# Altium schematic sheet BSPD.SchDoc
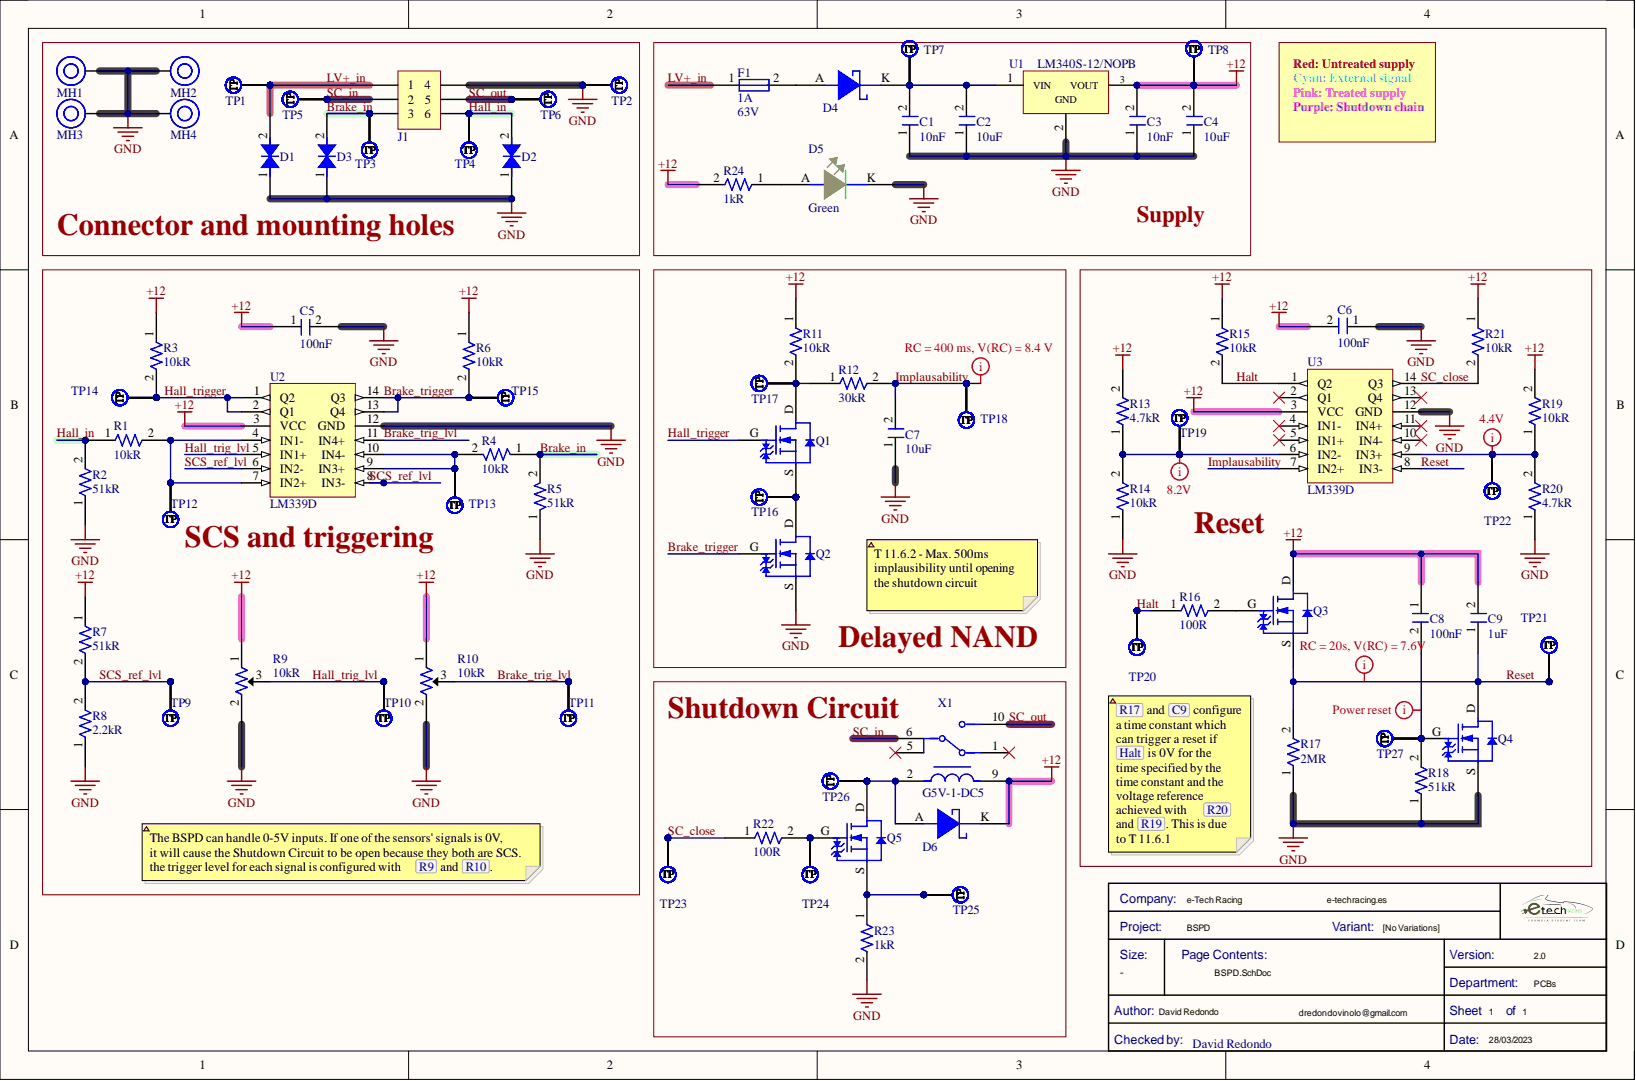
[diagram p0: BSPD.SchDoc]

## Parts
C1  Capacitance: 10nF  [C1206FNPC-RW]  (hidden comment: 885012008055)
C2  Capacitance: 10uF  [C10u-50V-1210FNPMLCC-X7R]  (hidden comment: GRJ32ER71H106KE11L)
C3  Capacitance: 10nF  [C1206FNPC-RW]  (hidden comment: 885012008055)
C4  Capacitance: 10uF  [C10u-50V-1210FNPMLCC-X7R]  (hidden comment: GRJ32ER71H106KE11L)
C5  Capacitance: 100nF  [C1206FNPC-RW]  (hidden comment: 885012208058)
C6  Capacitance: 100nF  [C1206FNPC-RW]  (hidden comment: 885012208058)
C7  Capacitance: 10uF  [C1206FNPC-RW]  (hidden comment: 885012208018)
C8  Capacitance: 100nF  [C1206FNPC-RW]  (hidden comment: 885012208058)
C9  Capacitance: 1uF  [C1206FNPC-RW]  (hidden comment: 885012208036)
D1  824551301  [DTVS-DO-214AB]  (value not drawn)
D2  824501600  [DTVS-DO-214AC]  (value not drawn)
D3  824501600  [DTVS-DO-214AC]  (value not drawn)
D4  MBR0530  [DSC-SOD123]  (value not drawn)
D5  Color: Green  [LED_0805_WE]  (hidden comment: 150080VS75000)
D6  MBR0530  [DSC-SOD123]  (value not drawn)
F1  Current Rating: 1A  Voltage Rating (AC): 63V  [Fuse_1206]  (hidden comment: 0437001.WRA)
J1  J_NanoFit_2x3  [J_NanoFit_2x3]  (value not drawn)
MH1  Mounting_Hole_M3  [Mounting_Hole_M3]  (value not drawn)
MH2  Mounting_Hole_M3  [Mounting_Hole_M3]  (value not drawn)
MH3  Mounting_Hole_M3  [Mounting_Hole_M3]  (value not drawn)
MH4  Mounting_Hole_M3  [Mounting_Hole_M3]  (value not drawn)
Q1  CPH3455-TL-H  [TRT-NCH-3/35-CPH]  (value not drawn)
Q2  CPH3455-TL-H  [TRT-NCH-3/35-CPH]  (value not drawn)
Q3  CPH3455-TL-H  [TRT-NCH-3/35-CPH]  (value not drawn)
Q4  CPH3455-TL-H  [TRT-NCH-3/35-CPH]  (value not drawn)
Q5  CPH3455-TL-H  [TRT-NCH-3/35-CPH]  (value not drawn)
R1  Resistance: 10kR  [R1206FTK-W]  (hidden comment: CRCW120610K0FKEA)
R2  Resistance: 51kR  [R1206FTK-W]  (hidden comment: CRCW120610K0FKEA)
R3  Resistance: 10kR  [R1206FTK-W]  (hidden comment: CRCW120610K0FKEA)
R4  Resistance: 10kR  [R1206FTK-W]  (hidden comment: CRCW120610K0FKEA)
R5  Resistance: 51kR  [R1206FTK-W]  (hidden comment: CRCW120610K0FKEA)
R6  Resistance: 10kR  [R1206FTK-W]  (hidden comment: CRCW120610K0FKEA)
R7  Resistance: 51kR  [R1206FTK-W]  (hidden comment: CRCW120610K0FKEA)
R8  Resistance: 2.2kR  [R1206FTK-W]  (hidden comment: CR1206-FX-2201ELF)
R9  Resistance: 10kR  [R10k-VTR]  (hidden comment: 3296W-1-103LF)
R10  Resistance: 10kR  [R10k-VTR]  (hidden comment: 3296W-1-103LF)
R11  Resistance: 10kR  [R1206FTK-W]  (hidden comment: CRCW120610K0FKEA)
R12  Resistance: 30kR  [R1206FTK-W]  (hidden comment: CRCW120610K0FKEA)
R13  Resistance: 4.7kR  [R1206FTK-W]  (hidden comment: CRS1206-FX-4701ELF)
R14  Resistance: 10kR  [R1206FTK-W]  (hidden comment: CRCW120610K0FKEA)
R15  Resistance: 10kR  [R1206FTK-W]  (hidden comment: CRCW120610K0FKEA)
R16  Resistance: 100R  [R1206FTK-W]  (hidden comment: CRG1206F100R)
R17  Resistance: 2MR  [R1206FTK-W]  (hidden comment: HVC1206-2M0FT3)
R18  Resistance: 51kR  [R1206FTK-W]  (hidden comment: CRCW120610K0FKEA)
R19  Resistance: 10kR  [R1206FTK-W]  (hidden comment: CRCW120610K0FKEA)
R20  Resistance: 4.7kR  [R1206FTK-W]  (hidden comment: CRS1206-FX-4701ELF)
R21  Resistance: 10kR  [R1206FTK-W]  (hidden comment: CRCW120610K0FKEA)
R22  Resistance: 100R  [R1206FTK-W]  (hidden comment: CRG1206F100R)
R23  Resistance: 1kR  [R1206FTK-W]  (hidden comment: ERJT08J102V)
R24  Resistance: 1kR  [R0805FTK]  (hidden comment: CR0805-JW-102ELF)
TP1  TestPoint  [TestPoint]  (value not drawn)  (no BOM)
TP2  TestPoint  [TestPoint]  (value not drawn)  (no BOM)
TP3  TestPoint  [TestPoint]  (value not drawn)  (no BOM)
TP4  TestPoint  [TestPoint]  (value not drawn)  (no BOM)
TP5  TestPoint  [TestPoint]  (value not drawn)  (no BOM)
TP6  TestPoint  [TestPoint]  (value not drawn)  (no BOM)
TP7  TestPoint  [TestPoint]  (value not drawn)  (no BOM)
TP8  TestPoint  [TestPoint]  (value not drawn)  (no BOM)
TP9  TestPoint  [TestPoint]  (value not drawn)  (no BOM)
TP10  TestPoint  [TestPoint]  (value not drawn)  (no BOM)
TP11  TestPoint  [TestPoint]  (value not drawn)  (no BOM)
TP12  TestPoint  [TestPoint]  (value not drawn)  (no BOM)
TP13  TestPoint  [TestPoint]  (value not drawn)  (no BOM)
TP14  TestPoint  [TestPoint]  (value not drawn)  (no BOM)
TP15  TestPoint  [TestPoint]  (value not drawn)  (no BOM)
TP16  TestPoint  [TestPoint]  (value not drawn)  (no BOM)
TP17  TestPoint  [TestPoint]  (value not drawn)  (no BOM)
TP18  TestPoint  [TestPoint]  (value not drawn)  (no BOM)
TP19  TestPoint  [TestPoint]  (value not drawn)  (no BOM)
TP20  TestPoint  [TestPoint]  (value not drawn)  (no BOM)
TP21  TestPoint  [TestPoint]  (value not drawn)  (no BOM)
TP22  TestPoint  [TestPoint]  (value not drawn)  (no BOM)
TP23  TestPoint  [TestPoint]  (value not drawn)  (no BOM)
TP24  TestPoint  [TestPoint]  (value not drawn)  (no BOM)
TP25  TestPoint  [TestPoint]  (value not drawn)  (no BOM)
TP26  TestPoint  [TestPoint]  (value not drawn)  (no BOM)
TP27  TestPoint  [TestPoint]  (value not drawn)  (no BOM)
U1  LM340S-12/NOPB  [LDO_35V-1/12V-TO220]
U2  LM339D  [Array_4_Amp_LM339D]
U3  LM339D  [Array_4_Amp_LM339D]
X1  G5V-1-DC5  [Relay_G5V-1-DC5]

## Nets
+12: C3.2 C4.2 C5.1 C6.2 C8.1 C9.2 D6.K Q3.D R3.1 R6.1 R7.1 R9.1 R10.1 R11.1 R13.2 R15.1 R19.2 R21.1 R24.2 TP8.1 U1.3 U2.3(VCC) U3.3(VCC) X1.9
GND: C1.1 C2.1 C3.1 C4.1 C5.2 C6.1 C7.1 D1.1 D2.1 D3.1 D5.K J1.4 MH1.1 MH2.1 MH3.1 MH4.1 Q2.S Q4.S R2.1 R5.1 R8.1 R9.2 R10.2 R14.1 R17.1 R18.1 R20.1 R23.2 TP2.1 U1.2 U2.12(GND) U3.12(GND)
Brake_in: D3.2 J1.3 R4.1 R5.2 TP3.1
Brake_trig_lvl: R10.3 TP11.1 U2.11(IN4+)
Brake_trigger: Q2.G R6.2 TP15.1 U2.13(Q4) U2.14(Q3)
Hall_in: D2.2 J1.6 R1.1 R2.2 TP4.1
Hall_trig_lvl: R9.3 TP10.1 U2.5(IN1+)
Hall_trigger: Q1.G R3.2 TP14.1 U2.1(Q2) U2.2(Q1)
Halt: R15.2 R16.1 TP20.1 U3.1(Q2)
Implausability: C7.2 R12.2 TP18.1 U3.7(IN2+)
LV+_in: D1.2 F1.1 J1.1 TP1.1
Reset: C9.1 Q3.S Q4.D R17.2 TP21.1 U3.8(IN3-)
SC_close: R21.2 R22.1 TP23.1 U3.14(Q3)
SC_in: J1.2 TP5.1 X1.6
SC_out: J1.5 TP6.1 X1.10
SCS_ref_lvl: R7.2 R8.2 TP9.1 U2.6(IN2-) U2.8(IN3-)
NetC1_2: C1.2 C2.2 D4.K TP7.1 U1.1
NetC8_2: C8.2 Q4.G R18.2 TP27.1
NetD4_A: D4.A F1.2
NetD5_A: D5.A R24.1
NetD6_A: D6.A Q5.D TP26.1 X1.2
NetQ1_D: Q1.D R11.2 R12.1 TP17.1
NetQ1_S: Q1.S Q2.D TP16.1
NetQ3_G: Q3.G R16.2
NetQ5_G: Q5.G R22.2 TP24.1
NetQ5_S: Q5.S R23.1 TP25.1
NetR1_2: R1.2 TP12.1 U2.4(IN1-) U2.7(IN2+)
NetR4_2: R4.2 TP13.1 U2.9(IN3+) U2.10(IN4-)
NetR13_1: R13.1 R14.2 TP19.1 U3.6(IN2-)
NetR19_1: R19.1 R20.2 TP22.1 U3.9(IN3+)

Not connected: U3 2(Q1) 4(IN1-) 5(IN1+) 10(IN4-) 11(IN4+) 13(Q4); X1 1 5

## Library data of the parts
C1 C3 = C10n-50V-1206FNPC-C0G/NP0: Cap Cer 10000PF 50V C0G/NP0 1206; Manufacturer: Wurth Electronics; .Creator: DO; Tolerance: 5%; Case Code (Imperial): 1206; Case Code (Metric): 3216; Case/Package: 1206; Category: Ceramic Capacitors; Component Kind: Standard; ComponentLink1Description: https://katalog.we-online.de/pbs/datasheet/885012008055.pdf?src-supplier=Digi-Key; ComponentLink1URL: https://katalog.we-online.de/pbs/datasheet/885012008055.pdf?src-supplier=Digi-Key; ComponentLink2Description: Where buy it; ComponentLink2URL: https://www.we-online.com/catalog/en/WCAP-CSGP-50VDC#885012207092; Length: 0.126inch; Library Name: Passives.SchLib; Manufacturer Part Number: 885012008055; Max Operating Temperature: 125°C; Min Operating Temperature: -55°C; Mount: Surface Mount; Packaging: Tape and Reel; Pricing 1: 1=0,40000, 10=0,35800, 50=0,35800, 100=0,32100, 1000=0,28400, 10000=0,17200 (USD); RoHS: Yes; Stock 1: 4648; Supplier 1: Mouser; Supplier Part Number 1: 710-885012008055; Thickness: 0.053inch; Voltage Rating: 50V; Width: 0.063inch
C2 C4 = C10u-50V-1210FNPMLCC-X7R: Multilayer Ceramic Capacitors MLCC - SMD/SMT 1210 10uF 50volts X7R +/-10% Soft Term; Manufacturer: Murata; .Creator: DR; Tolerance: 10%; Voltage Rating: 50V; Case Code (Imperial): 1210; Case Code (Metric): 3225; Case/Package: 1210; Category: Ceramic Capacitors; Component Kind: Standard; ComponentLink1Description: Datasheet; ComponentLink1URL: https://eu.mouser.com/datasheet/2/281/GRJ32ER71H106KE11_01-1977929.pdf; Features: Soft Termination; Length: 0.126inch; Library Name: Passives.SchLib; Manufacturer Part Number: GRJ32ER71H106KE11L; Max Operating Temperature: 125°C; Min Operating Temperature: -55°C; Mount: Surface Mount; Packaging: Tape and Reel; Pricing 1: 1000=0,33327, 2000=0,32389, 4000=0,31450, 6000=0,30511, 8000=0,29572 (USD); RoHS: Yes; RoHS Compliant: Yes; Stock 1: 19000; Supplier 1: Avnet; Supplier Part Number 1: GRJ32ER71H106KE11L; Thickness: 0.11inch; Width: 0.098inch
C5 C6 C8 = C100n-25V-1206FNPC-X7R: Cap Cer 0.1UF 25V X7R 1206; Manufacturer: Wurth Electronics; .Creator: DO; Tolerance: 10%; Case Code (Imperial): 1206; Case Code (Metric): 3216; Case/Package: 1206; Category: Capacitor; Component Kind: Standard; ComponentLink1Description: Datasheet; ComponentLink1URL: https://www.we-online.com/catalog/datasheet/885012208058.pdf; ComponentLink2Description: Where buy it; ComponentLink2URL: https://www.we-online.com/catalog/en/WCAP-CSGP-25VDC#885012208058; ComponentLink3Description: https://katalog.we-online.de/pbs/datasheet/885012208058.pdf?src-supplier=Digi-Key; ComponentLink3URL: https://katalog.we-online.de/pbs/datasheet/885012208058.pdf?src-supplier=Digi-Key; Length: 0.126inch; Library Name: Passives.SchLib; Manufacturer Part Number: 885012208058; Max Operating Temperature: 125°C; Min Operating Temperature: -55°C; Mount: Surface Mount; Packaging: Tape and Reel; Pricing 1: 1=0,11000, 10=0,10100, 100=0,09100, 500=0,08000 (USD); RoHS: Yes; RoHS Compliant: Yes; Stock 1: 4375; Supplier 1: Digi-Key; Supplier Part Number 1: 732-8109-1-ND; Thickness: 0.035inch; Voltage Rating: 25V; Width: 0.063inch
C7 = C10u-10V-1206FNPC-X7R: Cap, 10ΜF, 10V, 10%, X7R, 1206; Manufacturer 1: Wurth Electronics; .Creator: GP; Case Code (Imperial): 1206; Case Code (Metric): 3216; Case/Package: 1206; Category: Ceramic Capacitors; Component Kind: Standard; ComponentLink1Description: http://www.farnell.com/datasheets/2031895.pdf?src-supplier=Farnell; ComponentLink1URL: http://www.farnell.com/datasheets/2031895.pdf?src-supplier=Farnell; ComponentLink2Description: https://katalog.we-online.de/pbs/datasheet/885012208018.pdf?src-supplier=Digi-Key; ComponentLink2URL: https://katalog.we-online.de/pbs/datasheet/885012208018.pdf?src-supplier=Digi-Key; ComponentLink3Description: http://www.farnell.com/datasheets/2031895.pdf?src-supplier=Newark; ComponentLink3URL: http://www.farnell.com/datasheets/2031895.pdf?src-supplier=Newark; ComponentLink4Description: Wurth Elektronik; ComponentLink4URL: https://www.we-online.com/catalog/en/WCAP-CSGP-10VDC?sq=885012208018#885012208018; Length: 0.126inch; Library Name: Passives.SchLib; Manufacturer Part Number 1: 885012208018; Max Operating Temperature: 125°C; Min Operating Temperature: -55°C; Mount: Surface Mount; Packaging: Tape and Reel; Pricing 1: 1=0,66000, 10=0,59800, 100=0,53600, 500=0,47400 (USD); RoHS: Yes; RoHS Compliant: Yes; Stock 1: 7; Supplier 1: Digi-Key; Supplier Part Number 1: 732-7565-1-ND; Thickness: 0.075inch; Tolerance: 10%; Voltage Rating: 10V; Width: 0.063inch
C9 = C1u-16V-1206FNPC-X7R: Cap Cer 1UF 16V X7R 1206; Manufacturer: Wurth Electronics; .Creator: GP; Tolerance: 10%; Case Code (Imperial): 1206; Case Code (Metric): 3216; Case/Package: 1206; Category: Capacitor; Component Kind: Standard; ComponentLink1Description: Datasheet; ComponentLink1URL: https://www.we-online.com/catalog/datasheet/885012208036.pdf; ComponentLink2Description: Where buy it; ComponentLink2URL: https://www.we-online.com/catalog/en/WCAP-CSGP-16VDC#885012208036; Length: 0.126inch; Library Name: Passives.SchLib; Manufacturer Part Number: 885012208036; Max Operating Temperature: 125°C; Min Operating Temperature: -55°C; Mount: Surface Mount; Packaging: Tape and Reel; Pricing 1: 1=0,15000, 10=0,13900, 50=0,13900, 100=0,12400, 500=0,11000, 1000=0,11000, 3000=0,09100, 10000=0,09100 (USD); RoHS: Yes; RoHS Compliant: Yes; Stock 1: 5938; Supplier 1: Mouser; Supplier Part Number 1: 710-885012208036; Thickness: 0.051inch; Voltage Rating: 16V; Width: 0.063inch
D1 = DTVS-40V-DO-214AB: TVS diode [Würth Elektronik] 824551301 TVS diode; Manufacturer: Wurth Electronics; .Creator: DO; Clamping Voltage: 48.4V; Max Breakdown Voltage: 35.05V; Number of Bidirectional Channels: 1; Peak Pulse Current: 62A; Peak Pulse Power: 3kW; Polarity: Bidirectional; Power Line Protection: No; Reverse Standoff Voltage: 30V; Category: TVS Diodes; Component Kind: Standard; ComponentLink1Description: http://www.farnell.com/datasheets/2036459.pdf?src-supplier=Element14; ComponentLink1URL: http://www.farnell.com/datasheets/2036459.pdf?src-supplier=Element14; ComponentLink2Description: Where buy it; ComponentLink2URL: https://www.we-online.com/catalog/en/WE-TVSP?sq=824551301#824551301; Library Name: Filter components.SchLib; Manufacturer Part Number: 824551301; Max Operating Temperature: 150°C; Min Breakdown Voltage: 35.05V; Min Operating Temperature: -65°C; Mount: Surface Mount; Number of Pins: 2; Packaging: Cut Tape; Pricing 1: 10=1,72500, 50=1,54700, 100=1,45300, 250=1,35100, 500=1,15000 (USD); REACH SVHC: Unknown; Resistor Type: Zener; RoHS: Yes; RoHS Compliant: Yes; Stock 1: 1; Supplier 1: RSComponents; Supplier Part Number 1: 9217092P
D2 D3 = DTVS-6V-DO-214AC: TVS diode [Würth Elektronik] 824501600 TVS diode; Manufacturer: Wurth Electronics; .Creator: DO; Clamping Voltage: 10.3V; Max Breakdown Voltage: 7.02V; Number of Bidirectional Channels: 1; Peak Pulse Current: 38.8A; Peak Pulse Power: 400W; Polarity: Bidirectional; Power Line Protection: No; Reverse Standoff Voltage: 6V; Category: TVS Diodes; Component Kind: Standard; ComponentLink1Description: Where buy it; ComponentLink1URL: https://www.we-online.com/catalog/en/WE-TVSP?sq=824501600#824501600; ComponentLink2Description: http://www.farnell.com/datasheets/2036401.pdf?src-supplier=Element14; ComponentLink2URL: http://www.farnell.com/datasheets/2036401.pdf?src-supplier=Element14; ComponentLink3Description: https://katalog.we-online.de/pbs/datasheet/824501600.pdf?src-supplier=Digi-Key; ComponentLink3URL: https://katalog.we-online.de/pbs/datasheet/824501600.pdf?src-supplier=Digi-Key; Library Name: Filter components.SchLib; Manufacturer Part Number: 824501600; Max Operating Temperature: 150°C; Min Breakdown Voltage: 7.02V; Min Operating Temperature: -65°C; Mount: Surface Mount; Number of Pins: 2; Packaging: Cut Tape; Pricing 1: 1=0,28000, 10=0,26100, 50=0,26100, 100=0,23400, 500=0,20400, 1000=0,17400, 10000=0,17400 (USD); REACH SVHC: Unknown; Resistor Type: Zener; RoHS: Yes; RoHS Compliant: Yes; Stock 1: 780; Supplier 1: Mouser; Supplier Part Number 1: 710-824501600
D4 D6 = DSC-0.5/30-SOD123: VR=30V IF=500mA 30pF P=410mW; .Creator: GP; Average Rectified Current: 500mA; Element Configuration: Single; Forward Voltage: 430mV; Max Forward Surge Current (Ifsm): 5.5A; Max Repetitive Reverse Voltage (Vrrm): 30V; Max Reverse Current: 130uA; Polarity: Standard; Category: Diode; Component Kind: Standard; ComponentLink1Description: Datasheet; ComponentLink2Description: Where buy it; ComponentLink3Description: https://datasheet.ciiva.com/30479/0900766b810e2512-30479401.pdf?src-supplier=RSComponents; ComponentLink3URL: https://datasheet.ciiva.com/30479/0900766b810e2512-30479401.pdf?src-supplier=RSComponents; ComponentLink4Description: https://datasheet.ciiva.com/34301/974753-34301826.pdf?src-supplier=Jameco; ComponentLink4URL: https://datasheet.ciiva.com/34301/974753-34301826.pdf?src-supplier=Jameco; ComponentLink5Description: https://datasheet.ciiva.com/910/mbr0530-910516.pdf?src-supplier=Digi-Key; ComponentLink5URL: https://datasheet.ciiva.com/910/mbr0530-910516.pdf?src-supplier=Digi-Key; ComponentLink6Description: https://datasheet.ciiva.com/25931/mbr0530-25931989.pdf?src-supplier=Avnet+Europe; ComponentLink6URL: https://datasheet.ciiva.com/25931/mbr0530-25931989.pdf?src-supplier=Avnet+Europe; ComponentLink7Description: https://datasheet.ciiva.com/8300/mbr0530-8300905.pdf?src-supplier=Avnet; ComponentLink7URL: https://datasheet.ciiva.com/8300/mbr0530-8300905.pdf?src-supplier=Avnet; ComponentLink8Description: https://datasheet.ciiva.com/17318/mbr0530-17318568.pdf?src-supplier=Future+Electronics; ComponentLink8URL: https://datasheet.ciiva.com/17318/mbr0530-17318568.pdf?src-supplier=Future+Electronics; ComponentLink9Description: https://datasheet.ciiva.com/15144/getdatasheetpartid-86760-15144598.pdf?src-supplier=Verical; ComponentLink9URL: https://datasheet.ciiva.com/15144/getdatasheetpartid-86760-15144598.pdf?src-supplier=Verical; ComponentLink10Description: https://datasheet.ciiva.com/910/mbr0530-910518.pdf?src-supplier=Digi-Key; ComponentLink10URL: https://datasheet.ciiva.com/910/mbr0530-910518.pdf?src-supplier=Digi-Key; Contact Plating: Tin,Matte; Current Rating: 500mA; Forward Current: 500mA; Height: 1.03mm; Lead Free: Lead Free; Length: 2.7mm; Library Name: Filter components.SchLib; Max Reverse Leakage Current: 130uA; Max Reverse Voltage (DC): 30V; Max Surge Current: 5.5A; Mount: Surface Mount; Output Current: 500mA; Package Quantity: 1; Peak Non-Repetitive Surge Current: 5.5A; Pricing 1: 1=0,34400, 10=0,23900, 100=0,12200, 500=0,10000 (USD); Radiation Hardening: No; REACH SVHC: No SVHC; RoHS Compliant: Yes; Series: -; Stock 1: 9; Supplier 1: Newark; Supplier Part Number 1: 15R3453; Voltage Rating (DC): 30V; Weight: 0.03g; Width: 1.6mm
D5 = LEDVS-0805-40: Led, Green, 570 Nm, 2 V, 30 Ma, 40 Mcd Rohs Compliant: Yes; Manufacturer 1: Wurth Electronics; .Creator: DR; Dominant Wavelength: 570nm; Forward Current: 30mA; Forward Voltage: 2V; Lens Style: Clear,Rectangular; Luminous Intensity: 40mcd; Test Current: 20mA; Viewing Angle: 140°; Case Code (Imperial): 0805; Case Code (Metric): 2012; Case/Package: 0805; Category: LEDs; Component Kind: Standard; ComponentLink1Description: Datasheet; ComponentLink1URL: https://www.we-online.com/catalog/datasheet/150080VS75000.pdf; ComponentLink2Description: Where buy it; ComponentLink2URL: https://katalog.we-online.de/en/led/WL-SMCW/150080VS75000; ComponentLink3Description: https://datasheet.ciiva.com/22656/150080vs75000-22656966.pdf?src-supplier=Element14; ComponentLink3URL: https://datasheet.ciiva.com/22656/150080vs75000-22656966.pdf?src-supplier=Element14; ComponentLink4Description: https://datasheet.ciiva.com/29279/759437-da-01-en-chipled-0805-w-kl-h-gruen-150080vs7500-29279047.pdf?src-supplier=Conrad; ComponentLink4URL: https://datasheet.ciiva.com/29279/759437-da-01-en-chipled-0805-w-kl-h-gruen-150080vs7500-29279047.pdf?src-supplier=Conrad; ComponentLink5Description: https://datasheet.ciiva.com/29563/150080vs75000-29563018.pdf?src-supplier=Digi-Key; ComponentLink5URL: https://datasheet.ciiva.com/29563/150080vs75000-29563018.pdf?src-supplier=Digi-Key; Height: 0.7mm; Length: 2mm; Library Name: Semiconductor.SchLib; Manufacturer Part Number 1: 150080VS75000; Max Operating Temperature: 85°C; Min Operating Temperature: -40°C; Mount: Surface Mount; Package Quantity: 4000; Packaging: Tape and Reel; Pricing 1: 50=0,19300, 500=0,12900, 1000=0,12300, 2000=0,11700, 4000=0,11100 (USD); REACH SVHC: Unknown; RoHS: Yes; Stock 1: 850; Supplier 1: RSComponents; Supplier Part Number 1: 8154237; Wavelength: 570nm; Width: 1.25mm
F1 = Fuse_1A_63V_1206: Fuse Chip Fast Acting 1A 63V SMD Solder Pad 1206 Ceramic T/R Automotive; Manufacturer: Littelfuse; .Creator: DO; Approvals: CSA,cURus; Fuse Type: Board Mount (Cartridge Style Excluded); Case Code (Imperial): 1206; Case Code (Metric): 3216; Case/Package: 1206; Category: Fuses; Component Kind: Standard; ComponentLink1Description: https://www.littelfuse.com/~/media/electronics/datasheets/fuses/littelfuse_fuse_437a_datasheet.pdf.pdf?src-supplier=Digi-Key; ComponentLink1URL: https://www.littelfuse.com/~/media/electronics/datasheets/fuses/littelfuse_fuse_437a_datasheet.pdf.pdf?src-supplier=Digi-Key; ComponentLink2Description: Where buy it; ComponentLink2URL: https://www.mouser.es/ProductDetail/Littelfuse/0437001WRA?qs=DXv0QSHKF4z9CJhPXjxR%252BA%3D%3D; Library Name: Filter components.SchLib; Manufacturer Part Number: 0437001.WRA; Max Operating Temperature: 150°C; Min Operating Temperature: -55°C; Mount: Surface Mount; Packaging: Tape and Reel; Pricing 1: 1=1,52000, 5=1,47000, 10=1,37600, 50=1,13860, 100=0,94880, 250=0,92508, 500=0,78276, 1000=0,68788 (USD); RoHS: Yes; Stock 1: 5820; Supplier 1: Digi-Key; Supplier Part Number 1: F10001CT-ND; Voltage Rating (DC): 63V
Q1-Q5 = TRT-NCH-3/35-CPH: CPH3455-TL-H N-channel MOSFET Transistor; 3 A; 35 V; 3-Pin CPH; Manufacturer: ON Semiconductor; .Creator: GP; Continuous Drain Current (ID): 3A; Drain to Source Breakdown Voltage: 35V; Drain to Source Resistance: 108mR; Element Configuration: Single; Fall Time: 5.7ns; Gate to Source Voltage (Vgs): 20V; Input Capacitance: 186pF; Max Power Dissipation: 1W; Rds On Max: 104mR; Rise Time: 4.7ns; Turn-Off Delay Time: 15ns; Turn-On Delay Time: 4.2ns; Case/Package: SC; Category: Transistor; Component Kind: Standard; ComponentLink1Description: Datasheet; ComponentLink1URL: https://docs.rs-online.com/5847/0900766b812d514d.pdf; ComponentLink2Description: Where buy it; ComponentLink2URL: https://es.rs-online.com/web/p/mosfet/8009989; ComponentLink3Description: https://datasheet.ciiva.com/947/cph3455-d-947201.pdf?src-supplier=Digi-Key; ComponentLink3URL: https://datasheet.ciiva.com/947/cph3455-d-947201.pdf?src-supplier=Digi-Key; ComponentLink4Description: https://datasheet.ciiva.com/4444/cph3455-d-91653-4444616.pdf?src-supplier=Mouser; ComponentLink4URL: https://datasheet.ciiva.com/4444/cph3455-d-91653-4444616.pdf?src-supplier=Mouser; ComponentLink5Description: https://datasheet.ciiva.com/11164/cph3455-d-11164889.pdf?src-supplier=Avnet; ComponentLink5URL: https://datasheet.ciiva.com/11164/cph3455-d-11164889.pdf?src-supplier=Avnet; Drain to Source Voltage (Vdss): 35V; Halogen Free: Halogen Free; Height: 0.9mm; Lead Free: Lead Free; Length: 2.9mm; Library Name: Semiconductor.SchLib; Manufacturer Part Number: CPH3455-TL-H; Max Operating Temperature: 150°C; Min Operating Temperature: -55°C; Number of Pins: 3; Package Quantity: 3000; Packaging: Tape and Reel; Polarity: N-CHANNEL; Power Dissipation: 1W; Pricing 1: 50=0,50400 (USD); RoHS: Yes; RoHS Compliant: Yes; Series: -; Stock 1: 1; Supplier 1: RSComponents; Supplier Part Number 1: 8009989; Width: 1.6mm
R1 R3 R4 R6 R11 R14 R15 R19 R21 = R10k-1206FTK: Res Thick Film 1206 10K Ohm 1% 0.25W(1/4W) +/-100ppm/ßC Pad SMD Automotive T/R; .Creator: GP; Temperature Coefficient: 100ppm/°C; Voltage Rating: 200V; Case Code (Imperial): 1206; Case Code (Metric): 3216; Case/Package: 1206; Category: Resistor; Component Kind: Standard; ComponentLink1Description: Datasheet; ComponentLink1URL: https://docs.rs-online.com/bca8/A700000006404581.pdf; ComponentLink2Description: Where buy it; ComponentLink2URL: https://es.rs-online.com/web/p/resistencias-de-montaje-en-superficie/6791765; Construction: Rectangular; Contact Plating: Tin; Depth: 1.6mm; Features: Automotive AEC-Q200; Height: 0.55mm; Lead Free: Lead Free; Length: 3.2mm; Library Name: Passives.SchLib; Military Standard: Not; Number of Pins: 2; Number of Terminations: 2; Package Quantity: 10; Package Shape: Rectangular; Radiation Hardening: No; REACH SVHC: Unknown; Resistance Tolerance: 1%; Resistor Type: Thick Film; RoHS Compliant: Yes; Series: CRCW; Size Code: 1206; Supplier 1: Allied Electronics; Supplier Part Number 1: 70201262; Terminal Finish: Tin; Termination: SMD/SMT; Voltage Rating (DC): 200V; Weight: 0.000353oz; Width: 0.063inch; Working Voltage: 200V
R2 R5 R7 R12 R18 = R51k-1206FTK: Res Thick Film 1206 51K Ohm 1% 1/4W ±100ppm/°C Molded SMD SMD Punched Carrier T/R; Manufacturer: Panasonic; Manufacturer Part Number: ERJ-S08F5102V; .Creator: DO; Current Rating: 2A; Max Power Dissipation: 250mW; Voltage Rating: 150V; Voltage Rating (DC): 200V; Case Code (Imperial): 1206; Case Code (Metric): 3216; Case/Package: 1206; Category: Resistor; Component Kind: Standard; ComponentLink1Description: Datasheet; ComponentLink1URL: https://docs.rs-online.com/bca8/A700000006404581.pdf; ComponentLink2Description: Where buy it; ComponentLink2URL: https://es.rs-online.com/web/p/resistencias-de-montaje-en-superficie/6791765; ComponentLink3Description: https://datasheet.ciiva.com/24023/aoa0000ce5-24023518.pdf?src-supplier=Mouser; ComponentLink3URL: https://datasheet.ciiva.com/24023/aoa0000ce5-24023518.pdf?src-supplier=Mouser; ComponentLink4Description: https://datasheet.ciiva.com/9189/aoa0000ce5-9189211.pdf?src-supplier=Avnet; ComponentLink4URL: https://datasheet.ciiva.com/9189/aoa0000ce5-9189211.pdf?src-supplier=Avnet; Construction: Rectangular; Contact Plating: Tin; Depth: 1.6mm; Features: Anti-Sulfur; Height: 0.028inch; Lead Free: Lead Free; Length: 3.2mm; Library Name: Passives.SchLib; Max Operating Temperature: 155°C; Military Standard: Not; Min Operating Temperature: -55°C; Mount: Surface Mount; Number of Terminations: 2; Package Quantity: 5000; Packaging: Tape and Reel; Power Rating: 250mW; Pricing 1: 1=0,31000, 10=0,26700, 50=0,26700, 100=0,10700, 1000=0,05100, 10000=0,03400 (USD); Resistor Type: Thick Film; RoHS: Yes; RoHS Compliant: Yes; Series: ERJ; Stock 1: 4091; Supplier 1: Mouser; Supplier Part Number 1: 667-ERJ-S08F5102V; Temperature Coefficient: 100ppm/°C; Tolerance: 1%; Width: 0.063inch
R8 = R2.2K-1206FTK: Res Thick Film 1206 2.2K Ohm 1% 1/4W ±100ppm/°C Molded SMD SMD Paper Tape on Plastic Reel; Manufacturer 1: Bourns; .Creator: AC; Max Power Dissipation: 250mW; Voltage Rating: 200V; Case Code (Imperial): 1206; Case Code (Metric): 3216; Case/Package: 1206; Category: Chip SMD Resistors; Component Kind: Standard; ComponentLink1Description: https://datasheet.ciiva.com/11809/0900766b80fcdb72-11809898.pdf?src-supplier=RSComponents; ComponentLink1URL: https://datasheet.ciiva.com/11809/0900766b80fcdb72-11809898.pdf?src-supplier=RSComponents; ComponentLink2Description: https://datasheet.ciiva.com/9123/chpreztr-9123227.pdf?src-supplier=Avnet; ComponentLink2URL: https://datasheet.ciiva.com/9123/chpreztr-9123227.pdf?src-supplier=Avnet; ComponentLink3Description: https://datasheet.ciiva.com/16770/70154685-16770447.pdf?src-supplier=Allied+Electronics; ComponentLink3URL: https://datasheet.ciiva.com/16770/70154685-16770447.pdf?src-supplier=Allied+Electronics; ComponentLink4Description: https://datasheet.ciiva.com/7985/1856384-7985879.pdf?src-supplier=Element14; ComponentLink4URL: https://datasheet.ciiva.com/7985/1856384-7985879.pdf?src-supplier=Element14; ComponentLink5Description: https://datasheet.ciiva.com/22693/1902866-22693258.pdf?src-supplier=Element14; ComponentLink5URL: https://datasheet.ciiva.com/22693/1902866-22693258.pdf?src-supplier=Element14; ComponentLink6Description: RS; ComponentLink6URL: https://es.rs-online.com/web/p/resistencias-fijas-para-montaje-en-superficie/7409097/; Construction: Rectangular; Contact Plating: Tin; Depth: 1.6mm; Features: Moisture Resistant; Height: 0.6mm; Length: 3.2mm; Library Name: Passives.SchLib; Manufacturer Part Number 1: CR1206-FX-2201ELF; Max Operating Temperature: 155°C; Military Standard: Not; Min Operating Temperature: -55°C; Mount: Surface Mount; Number of Pins: 2; Number of Terminations: 2; Package Quantity: 5000; Packaging: Tape and Reel; Power Rating: 250mW; Pricing 1: 1=0,10000, 10=0,04200, 100=0,01700, 1000=0,00762, 2500=0,00661 (USD); Radiation Hardening: No; REACH SVHC: No SVHC; Resistance Tolerance: 1%; Resistor Type: Thick Film; RoHS: Yes; RoHS Compliant: Yes; Series: CR1206; Stock 1: 150; Supplier 1: Digi-Key; Supplier Part Number 1: CR1206-FX-2201ELFCT-ND; Technology: THICK FILM; Temperature Coefficient: 100ppm/°C; Termination: SMD/SMT; Thickness: 610um; Tolerance: 1%; Voltage Rating (DC): 200V; Width: 1.6mm
R9 R10 = R10k-VTR: Trimmer, Cermet, Rest 10 Kilohms, PCB, 25 Turns, Pwr-Rtg 0.5W, 3296 Series; Manufacturer: Bourns; .Creator: DO; Insulation Resistance: 1GR; Max Power Dissipation: 500mW; Number of Turns: 25; Resistance Tolerance: 10%; Temperature Coefficient: 100ppm/°C; Category: Variable Resistors and Potentiometers; Color: Blue; Component Kind: Standard; ComponentLink1Description: https://datasheet.ciiva.com/19454/853572-19454866.pdf?src-supplier=Jameco; ComponentLink1URL: https://datasheet.ciiva.com/19454/853572-19454866.pdf?src-supplier=Jameco; ComponentLink2Description: https://datasheet.ciiva.com/11830/0900766b8069ccf4-11830871.pdf?src-supplier=RSComponents; ComponentLink2URL: https://datasheet.ciiva.com/11830/0900766b8069ccf4-11830871.pdf?src-supplier=RSComponents; ComponentLink3Description: https://datasheet.ciiva.com/29122/447277-da-01-en-trimmer-tht3296w-1-103lf-10k-0-5w-29122362.pdf?src-supplier=Conrad; ComponentLink3URL: https://datasheet.ciiva.com/29122/447277-da-01-en-trimmer-tht3296w-1-103lf-10k-0-5w-29122362.pdf?src-supplier=Conrad; ComponentLink4Description: https://datasheet.ciiva.com/26913/3296w-1-103lf-26913885.pdf?src-supplier=IIIC; ComponentLink4URL: https://datasheet.ciiva.com/26913/3296w-1-103lf-26913885.pdf?src-supplier=IIIC; ComponentLink5Description: https://datasheet.ciiva.com/1131/1815117-1131273.pdf?src-supplier=Element14; ComponentLink5URL: https://datasheet.ciiva.com/1131/1815117-1131273.pdf?src-supplier=Element14; ComponentLink6Description: https://datasheet.ciiva.com/18411/70153578-18411561.pdf?src-supplier=Allied+Electronics; ComponentLink6URL: https://datasheet.ciiva.com/18411/70153578-18411561.pdf?src-supplier=Allied+Electronics; ComponentLink7Description: https://datasheet.ciiva.com/3266/3296-3266187.pdf?src-supplier=Avnet; ComponentLink7URL: https://datasheet.ciiva.com/3266/3296-3266187.pdf?src-supplier=Avnet; ComponentLink8Description: https://datasheet.ciiva.com/30093/3296-30093899.pdf?src-supplier=Onlinecomponents.com; ComponentLink8URL: https://datasheet.ciiva.com/30093/3296-30093899.pdf?src-supplier=Onlinecomponents.com; ComponentLink9Description: https://datasheet.ciiva.com/2156/3296-2156893.pdf?src-supplier=Digi-Key; ComponentLink9URL: https://datasheet.ciiva.com/2156/3296-2156893.pdf?src-supplier=Digi-Key; ComponentLink10Description: https://datasheet.ciiva.com/14173/ew3296-dat-e-14173022.pdf?src-supplier=Distrelec; ComponentLink10URL: https://datasheet.ciiva.com/14173/ew3296-dat-e-14173022.pdf?src-supplier=Distrelec; ComponentLink11Description: Where buy it; ComponentLink11URL: https://es.rs-online.com/web/p/potenciometros-de-ajuste/5219647; Contact Plating: Tin,Matte; Depth: 4.83mm; Height: 0.395inch; Lead Free: Lead Free; Length: 9.53mm; Library Name: Passives.SchLib; Manufacturer Part Number: 3296W-1-103LF; Max Operating Temperature: 125°C; Military Standard: Not; Min Operating Temperature: -55°C; Mount: PCB,Through Hole; Package Quantity: 50; Packaging: Rail/Tube; Power Rating: 500mW; Pricing 1: 50=2,54800, 100=2,31900, 200=2,14000, 300=1,88400, 500=1,55300 (USD); Radiation Hardening: No; REACH SVHC: No SVHC; Resistor Type: Cermet; RoHS: Yes; RoHS Compliant: Yes; Series: 3296; Stock 1: 3300; Supplier 1: RSComponents; Supplier Part Number 1: 1673377; Taper: Linear; Technology: CERMET; Termination: Pin; Tolerance: 10%; Weight: 0.03oz; Width: 9.53mm
R13 R20 = R4.7K-1206FTK: Res Thick Film 1206 4.7K Ohm 1% 1/2W ±100ppm/°C Molded SMD T/R; Manufacturer 1: Bourns JW Miller; .Creator: AC; Max Power Dissipation: 500mW; Voltage Rating: 400V; Case Code (Imperial): 1206; Case Code (Metric): 3016; Case/Package: 1206; Category: Chip SMD Resistors; Component Kind: Standard; ComponentLink1Description: https://datasheet.ciiva.com/48986/70483705-48986735.pdf?src-supplier=Allied+Electronics; ComponentLink1URL: https://datasheet.ciiva.com/48986/70483705-48986735.pdf?src-supplier=Allied+Electronics; ComponentLink3Description: RS; ComponentLink3URL: https://es.rs-online.com/web/p/resistencias-de-montaje-en-superficie/8672965; Height: 0.026inch; Length: 0.122inch; Library Name: Passives.SchLib; Manufacturer Part Number 1: CRS1206-FX-4701ELF; Max Operating Temperature: 155°C; Min Operating Temperature: -55°C; Mount: Surface Mount; Number of Terminations: 2; Packaging: Cut Tape; Power Rating: 500mW; Pricing 1: 1=0,42000, 10=0,35400, 100=0,13850, 1000=0,05796, 2500=0,05313 (USD); REACH SVHC: No SVHC; Resistor Type: Thick Film; RoHS: Yes; Supplier 1: Digi-Key; Supplier Part Number 1: CRS1206-FX-4701ELFCT-ND; Temperature Coefficient: 100ppm/°C; Termination: SMD/SMT; Tolerance: 1%; Voltage Rating (DC): 400V; Width: 0.063inch; Working Voltage: 400V
R16 R22 = R100-1206FTK: Res Thick Film 1206 100 Ohm 1% 0.25W(1/4W) ±200ppm/C Pad SMD T/R; Manufacturer: TE Connectivity; .Creator: AC; Max Power Dissipation: 250mW; Number of Resistors: 1; Temperature Coefficient: 200ppm/°C; Voltage Rating: 200V; Case Code (Imperial): 1206; Case Code (Metric): 3216; Case/Package: 1206; Category: Resistor; Component Kind: Standard; ComponentLink1Description: Datasheet; ComponentLink1URL: https://docs.rs-online.com/7aab/0900766b815b7339.pdf; ComponentLink2Description: Where buy it; ComponentLink2URL: https://es.rs-online.com/web/p/resistencias-de-montaje-en-superficie/2232120; ComponentLink3Description: https://datasheet.ciiva.com/11808/0900766b800a696e-11808648.pdf?src-supplier=RSComponents; ComponentLink3URL: https://datasheet.ciiva.com/11808/0900766b800a696e-11808648.pdf?src-supplier=RSComponents; ComponentLink4Description: https://datasheet.ciiva.com/16763/70063020-16763547.pdf?src-supplier=Allied+Electronics; ComponentLink4URL: https://datasheet.ciiva.com/16763/70063020-16763547.pdf?src-supplier=Allied+Electronics; ComponentLink5Description: https://datasheet.ciiva.com/5562/eng-ds-1773204-g-5562604.pdf?src-supplier=Mouser; ComponentLink5URL: https://datasheet.ciiva.com/5562/eng-ds-1773204-g-5562604.pdf?src-supplier=Mouser; Depth: 1.55mm; ELV: Compliant; Height: 0.55mm; Length: 3.1mm; Library Name: Passives.SchLib; Manufacturer Part Number: CRG1206F100R; Max Operating Temperature: 125°C; Min Operating Temperature: -55°C; Mount: Surface Mount; Number of Terminations: 2; Package Quantity: 5000; Packaging: Tape and Reel; Power Rating: 250mW; Pricing 1: 1=0,10000, 10=0,07600, 100=0,02920, 1000=0,01285, 2500=0,01110 (USD); Radiation Hardening: No; Resistor Type: Thick Film; RoHS: Yes; RoHS Compliant: Yes; Series: CRG, Neohm; Stock 1: 13476; Supplier 1: Digi-Key; Supplier Part Number 1: A106068CT-ND; Technology: THICK FILM; Termination: Solder; Tolerance: 1%; Weight: 0.000571oz; Width: 1.55mm
R17 = R2M-1206FTK: Res Thick Film 1206 2M Ohm 1% 0.3W ±100ppm/C Pad SMD T/R; Manufacturer: TT Welwyn; .Creator: DO; Power Rating: 300mW; Temperature Coefficient: 100ppm/°C; Voltage Rating: 1kV; Case Code (Imperial): 1206; Case Code (Metric): 3216; Category: Uncategorized; Component Kind: Standard; ComponentLink1Description: Datasheet; ComponentLink1URL: https://www.murata.com/en-eu/api/pdfdownloadapi?cate=cgsubHighVoltageResistors&partno=MHR0307SA205G20; ComponentLink2Description: https://datasheet.ciiva.com/28677/1720556-28677405.pdf?src-supplier=Element14; ComponentLink2URL: https://datasheet.ciiva.com/28677/1720556-28677405.pdf?src-supplier=Element14; Library Name: Passives.SchLib; Manufacturer Part Number: HVC1206-2M0FT3; Packaging: Cut Tape; Pricing 1: 3000=1,11000 (USD); REACH SVHC: No SVHC; Resistence: 2MR; Resistor Type: Thick Film; RoHS: Yes; RoHS Compliant: Yes; Supplier 1: Future Electronics; Supplier Part Number 1: 6119491; Tolerance: 1%; Voltage Rating (DC): 1kV
R23 = R1k-1206FTK: Res Thick Film 1206 1K Ohm 5% 0.33W(1/3W) ±200ppm/C Pad SMD Automotive T/R; Manufacturer: Panasonic; .Creator: GP; Max Power Dissipation: 333mW; Power Rating: 330mW; Temperature Coefficient: 200ppm/°C; Voltage Rating: 200V; Case Code (Imperial): 1206; Case Code (Metric): 3216; Case/Package: 1206; Category: Resistor; Component Kind: Standard; ComponentLink1Description: Datasheet; ComponentLink1URL: https://docs.rs-online.com/ef78/0900766b812cb812.pdf; ComponentLink2Description: Where buy it; ComponentLink2URL: https://es.rs-online.com/web/p/resistencias-de-montaje-en-superficie/7218936; Contact Plating: Tin; Depth: 1.6mm; Features: Automotive AEC-Q200; Height: 0.6mm; Length: 3.2mm; Library Name: Passives.SchLib; Manufacturer Part Number: ERJT08J102V; Max Operating Temperature: 155°C; Military Standard: Not; Min Operating Temperature: -55°C; Mount: Surface Mount; Number of Pins: 2; Number of Terminations: 2; Package Quantity: 5000; Packaging: Tape and Reel; Pricing 1: 5=0,32200, 50=0,30000, 100=0,28100, 250=0,25900, 500=0,23800 (USD); Radiation Hardening: No; REACH SVHC: No SVHC; Resistor Type: Thick Film; RoHS: Yes; RoHS Compliant: Yes; Stock 1: 1; Supplier 1: RSComponents; Supplier Part Number 1: 7218936; Termination: Tab; Tolerance: 5%; Voltage Rating (DC): 200V; Width: 0.063inch
R24 = R1k-0805FTK: Res Thick Film 0805 1K Ohm 5% 1/8W ±200ppm/°C Molded SMD SMD Paper Tape on Plastic Reel; Manufacturer 1: Bourns; .Creator: GP; Max Power Dissipation: 125mW; Voltage Rating: 150V; Case Code (Imperial): 0805; Case Code (Metric): 2012; Case/Package: 0805; Category: Chip SMD Resistors; Component Kind: Standard; ComponentLink1Description: https://datasheet.ciiva.com/11821/0900766b80fcdb72-11821134.pdf?src-supplier=RSComponents; ComponentLink1URL: https://datasheet.ciiva.com/11821/0900766b80fcdb72-11821134.pdf?src-supplier=RSComponents; ComponentLink2Description: https://datasheet.ciiva.com/15225/getdatasheetpartid-78155-15225381.pdf?src-supplier=Verical; ComponentLink2URL: https://datasheet.ciiva.com/15225/getdatasheetpartid-78155-15225381.pdf?src-supplier=Verical; ComponentLink3Description: https://datasheet.ciiva.com/22693/1902866-22693253.pdf?src-supplier=Element14; ComponentLink3URL: https://datasheet.ciiva.com/22693/1902866-22693253.pdf?src-supplier=Element14; ComponentLink4Description: https://datasheet.ciiva.com/7986/1856384-7986184.pdf?src-supplier=Element14; ComponentLink4URL: https://datasheet.ciiva.com/7986/1856384-7986184.pdf?src-supplier=Element14; ComponentLink5Description: https://datasheet.ciiva.com/7985/1856384-7985867.pdf?src-supplier=Element14; ComponentLink5URL: https://datasheet.ciiva.com/7985/1856384-7985867.pdf?src-supplier=Element14; ComponentLink6Description: https://datasheet.ciiva.com/30242/159chpreztr-30242765.pdf?src-supplier=Onlinecomponents.com; ComponentLink6URL: https://datasheet.ciiva.com/30242/159chpreztr-30242765.pdf?src-supplier=Onlinecomponents.com; ComponentLink7Description: https://datasheet.ciiva.com/6547/chpreztr-6547359.pdf?src-supplier=Digi-Key; ComponentLink7URL: https://datasheet.ciiva.com/6547/chpreztr-6547359.pdf?src-supplier=Digi-Key; Contact Plating: Tin; Depth: 1.25mm; Features: Moisture Resistant; Height: 0.5mm; Lead Free: Lead Free; Length: 2mm; Library Name: Passives.SchLib; Manufacturer Part Number 1: CR0805-JW-102ELF; Max Operating Temperature: 155°C; Military Standard: Not; Min Operating Temperature: -55°C; Mount: Surface Mount; Number of Pins: 2; Number of Terminations: 2; Package Quantity: 1; Packaging: Tape and Reel; Power Rating: 125mW; Pricing 1: 1=0,05930, 10=0,03510, 25=0,01790, 100=0,00800, 250=0,00790, 500=0,00590, 1000=0,00360, 3000=0,00350, 6000=0,00250 (USD); Radiation Hardening: No; REACH SVHC: No SVHC; Resistor Type: Thick Film; RoHS: Yes; Stock 1: 27510; Supplier 1: Arrow Electronics; Supplier Part Number 1: CR0805-JW-102ELF; Temperature Coefficient: 200ppm/°C; Termination: SMD/SMT; Thickness: 508um; Tolerance: 5%; Voltage Rating (DC): 150V; Width: 1.25mm
TP1-TP27 = TestPoint: Test Point 1x1 mm; .Creator: GP; Category: TestPoint; Library Name: e-Tech_Racing.SchLib; .PCBcheck: GP; .SCHcheck: GP
U1 = LDO_35V-1/12V-TO220: 1.5-A, 35-V, linear voltage regulator 3-TO-220 -40 to 125; Manufacturer: Texas Instruments; .Creator: AC; Accuracy: 2%; Dropout Voltage: 2V; Max Input Voltage: 35V; Max Output Current: 1A; Number of Outputs: 1; Output Type: Fixed; Output Voltage: 12V; Polarity: Positive; Quiescent Current: 8mA; Case/Package: TO-220; Category: Voltage Regulators - Linear; Component Kind: Standard; ComponentLink1Description: https://datasheet.ciiva.com/16490/lm340-n-16490133.pdf?src-supplier=Avnet+Asia; ComponentLink1URL: https://datasheet.ciiva.com/16490/lm340-n-16490133.pdf?src-supplier=Avnet+Asia; ComponentLink2Description: Where buy it; ComponentLink2URL: https://es.rs-online.com/web/p/reguladores-de-tension/1977323; Height: 8.89mm; Lead Free: Lead Free; Length: 10.16mm; Library Name: Semiconductor.SchLib; Manufacturer Part Number: LM340S-12/NOPB; Max Operating Temperature: 125°C; Min Operating Temperature: -40°C; Mount: Through Hole; Number of Pins: 3; Output Current: 1A; Output Voltage Accuracy: 2%; Package Quantity: 45; Packaging: Rail/Tube; Pricing 1: 1=1,97000, 10=1,76700, 25=1,66680, 100=1,42020, 250=1,33348, 500=1,16680, 1000=1,10075 (USD); Radiation Hardening: No; RoHS: Yes; RoHS Compliant: Yes; Series: LM340-N; Supplier 1: Digi-Key; Supplier Part Number 1: 296-50628-ND; Voltage: 12V; Width: 4.7mm
U2 U3 = Array_4_Comp_LM339D: Comparator Quad ±18V/36V 14-Pin SOIC Tube; Manufacturer: Texas Instruments; .Creator: DO; Dual Supply Voltage: 9V; Input Bias Current: 250nA; Input Offset Voltage (Vos): 5mV; Max Dual Supply Voltage: 15V; Max Supply Current: 500uA; Max Supply Voltage: 30V; Min Dual Supply Voltage: 1V; Min Supply Voltage: 2V; Nominal Supply Current: 2mA; Number of Channels: 4; Output Current: 20mA; Output Current per Channel: 6mA; Output Type: TTL; Quiescent Current: 2.5mA; Response Time: 0.3us; Voltage Gain: 106.02dB; Case/Package: SOIC; Category: Amplifiers - Op Amps, Buffer, Instrumentation; Component Kind: Standard; ComponentLink1Description: https://datasheet.ciiva.com/15131/getdatasheetpartid-248452-15131099.pdf?src-supplier=Verical; ComponentLink1URL: https://datasheet.ciiva.com/15131/getdatasheetpartid-248452-15131099.pdf?src-supplier=Verical; ComponentLink2Description: https://datasheet.ciiva.com/1483/1831045-1483000.pdf?src-supplier=Element14; ComponentLink2URL: https://datasheet.ciiva.com/1483/1831045-1483000.pdf?src-supplier=Element14; ComponentLink3Description: https://datasheet.ciiva.com/1827/lm139a-1827534.pdf?src-supplier=Digi-Key; ComponentLink3URL: https://datasheet.ciiva.com/1827/lm139a-1827534.pdf?src-supplier=Digi-Key; ComponentLink4Description: https://datasheet.ciiva.com/2970/lm2901-2970484.pdf?src-supplier=Avnet; ComponentLink4URL: https://datasheet.ciiva.com/2970/lm2901-2970484.pdf?src-supplier=Avnet; ComponentLink5Description: https://datasheet.ciiva.com/48712/001010753-da-01-en-ic-quad-diff-comp-lm339d-soic-14-tid-48712470.pdf?src-supplier=Conrad; ComponentLink5URL: https://datasheet.ciiva.com/48712/001010753-da-01-en-ic-quad-diff-comp-lm339d-soic-14-tid-48712470.pdf?src-supplier=Conrad; ComponentLink6Description: Where buy it; ComponentLink6URL: https://es.rs-online.com/web/p/comparadores/0858411; Contact Plating: Gold; Height: 1.58mm; Lead Free: Lead Free; Length: 8.65mm; Library Name: Integrated circuits.SchLib; Manufacturer Part Number: LM339D; Max Input Current: 250nA; Max Operating Temperature: 70°C; Min Operating Temperature: 0°C; Mount: Surface Mount; Number of Elements: 4; Number of Pins: 14; Package Quantity: 50; Packaging: Rail/Tube; Pricing 1: 1=0,93000, 10=0,83500, 25=0,79240, 100=0,65090, 250=0,60848, 500=0,53774, 1000=0,46725 (USD); Radiation Hardening: No; REACH SVHC: No SVHC; RoHS: Yes; RoHS Compliant: Yes; Series: LM339; Stock 1: 10834; Supplier 1: Digi-Key; Supplier Part Number 1: 296-1013-5-ND; Supply Current: 2mA; Technology: BIPOLAR; Weight: 0.004318oz; Width: 3.91mm
X1 = Relay_G5V-1-DC5: Electromechanical Relay SPDT 1A 5VDC 167Ohm Through Hole; Manufacturer: Omron; .Creator: DO; Approvals: UL,CSA; Coil Current: 30mA; Coil Power: 150mW; Coil Resistance: 167R; Coil Type: Non-Latching; Coil Voltage (DC): 5V; Insulation Resistance: 1GR; Max Voltage Rating (AC): 125V; Max Voltage Rating (DC): 60V; Number of Poles: 1; Operate Time: 5ms; Relay Type: General Purpose; Case/Package: DIP; Category: Signal Relays; Component Kind: Standard; ComponentLink1Description: https://datasheet.ciiva.com/1525/96550-1525281.pdf?src-supplier=Element14; ComponentLink1URL: https://datasheet.ciiva.com/1525/96550-1525281.pdf?src-supplier=Element14; ComponentLink2Description: https://datasheet.ciiva.com/19455/187240-19455238.pdf?src-supplier=Jameco; ComponentLink2URL: https://datasheet.ciiva.com/19455/187240-19455238.pdf?src-supplier=Jameco; ComponentLink3Description: https://datasheet.ciiva.com/26921/getdatasheetpartid-765626-26921061.pdf?src-supplier=Verical; ComponentLink3URL: https://datasheet.ciiva.com/26921/getdatasheetpartid-765626-26921061.pdf?src-supplier=Verical; ComponentLink4Description: https://datasheet.ciiva.com/18421/70175634-18421511.pdf?src-supplier=Allied+Electronics; ComponentLink4URL: https://datasheet.ciiva.com/18421/70175634-18421511.pdf?src-supplier=Allied+Electronics; ComponentLink5Description: https://datasheet.ciiva.com/15718/g5v-1-15718184.pdf?src-supplier=Onlinecomponents.com; ComponentLink5URL: https://datasheet.ciiva.com/15718/g5v-1-15718184.pdf?src-supplier=Onlinecomponents.com; ComponentLink6Description: https://datasheet.ciiva.com/2416/g5v-1-0911-2416495.pdf?src-supplier=Digi-Key; ComponentLink6URL: https://datasheet.ciiva.com/2416/g5v-1-0911-2416495.pdf?src-supplier=Digi-Key; ComponentLink7Description: https://datasheet.ciiva.com/30083/en-g5v-1-30083503.pdf?src-supplier=Onlinecomponents.com; ComponentLink7URL: https://datasheet.ciiva.com/30083/en-g5v-1-30083503.pdf?src-supplier=Onlinecomponents.com; ComponentLink8Description: Where buy it; ComponentLink8URL: https://es.rs-online.com/web/p/reles-sin-enclavamiento/8411420/?relevancy-data=7365617263685F636173636164655F6F726465723D31267365617263685F696E746572666163655F6E616D653D4931384E53656172636847656E65726963267365617263685F6C616E67756167655F757365643D6573267365617263685F6D617463685F6D6F64653D6D61746368616C6C7061727469616C267365617263685F7061747465726E5F6D6174636865643D5E5B5C707B4C7D5C707B4E647D2D2C2F255C2E5D2B24267365617263685F7061747465726E5F6F726465723D313333267365617263685F73745F6E6F726D616C697365643D59267365617263685F726573706F6E73655F616374696F6E3D267365617263685F747970653D4B4559574F52445F53494E474C455F414C5048415F4E554D45524943267365617263685F7370656C6C5F636F72726563745F6170706C6965643D59267365617263685F77696C645F63617264696E675F6D6F64653D4E4F4E45267365617263685F6B6579776F72643D4735562D312D444335267365617263685F6B6579776F72645F6170703D4735562D312D444335267365617263685F636F6E6669673D3126&searchHistory=%7B%22enabled%22%3Atrue%7D; Contact Current Rating: 1A; Contact Material: Gold,Silver; Current Rating: 1A; Depth: 7.5mm; Height: 10mm; Lead Free: Lead Free; Length: 12.5mm; Library Name: Electromechanical.SchLib; Manufacturer Part Number: G5V-1-DC5; Max Current Rating: 1A; Max Operating Temperature: 70°C; Min Operating Temperature: -40°C; Mount: Through Hole,PCB; Number of Pins: 6; Package Quantity: 25; Packaging: Bulk; Power Consumption: 150mW; Pricing 1: 1=1,32941, 10=1,30681, 30=1,28421, 50=1,25430, 100=1,24300 (USD); Radiation Hardening: No; REACH SVHC: Unknown; Release Time: 5ms; RoHS: Yes; Stock 1: 10; Supplier 1: Avnet; Supplier Part Number 1: G5V-1-DC5; Termination: PC Pin; Throw Configuration: SPDT; Type: Electromechanical; Voltage Rating (AC): 125V; Voltage Rating (DC): 60V; Width: 7.5mm
J1 = J_NanoFit_2x3: Component Kind: Standard; Library Name: Electromechanical.SchLib
MH1-MH4 = Mounting_Hole_M3: Component Kind: Standard; Library Name: Electromechanical.SchLib
